# Altium schematic sheet Sheet1.SchDoc(Sheet1)
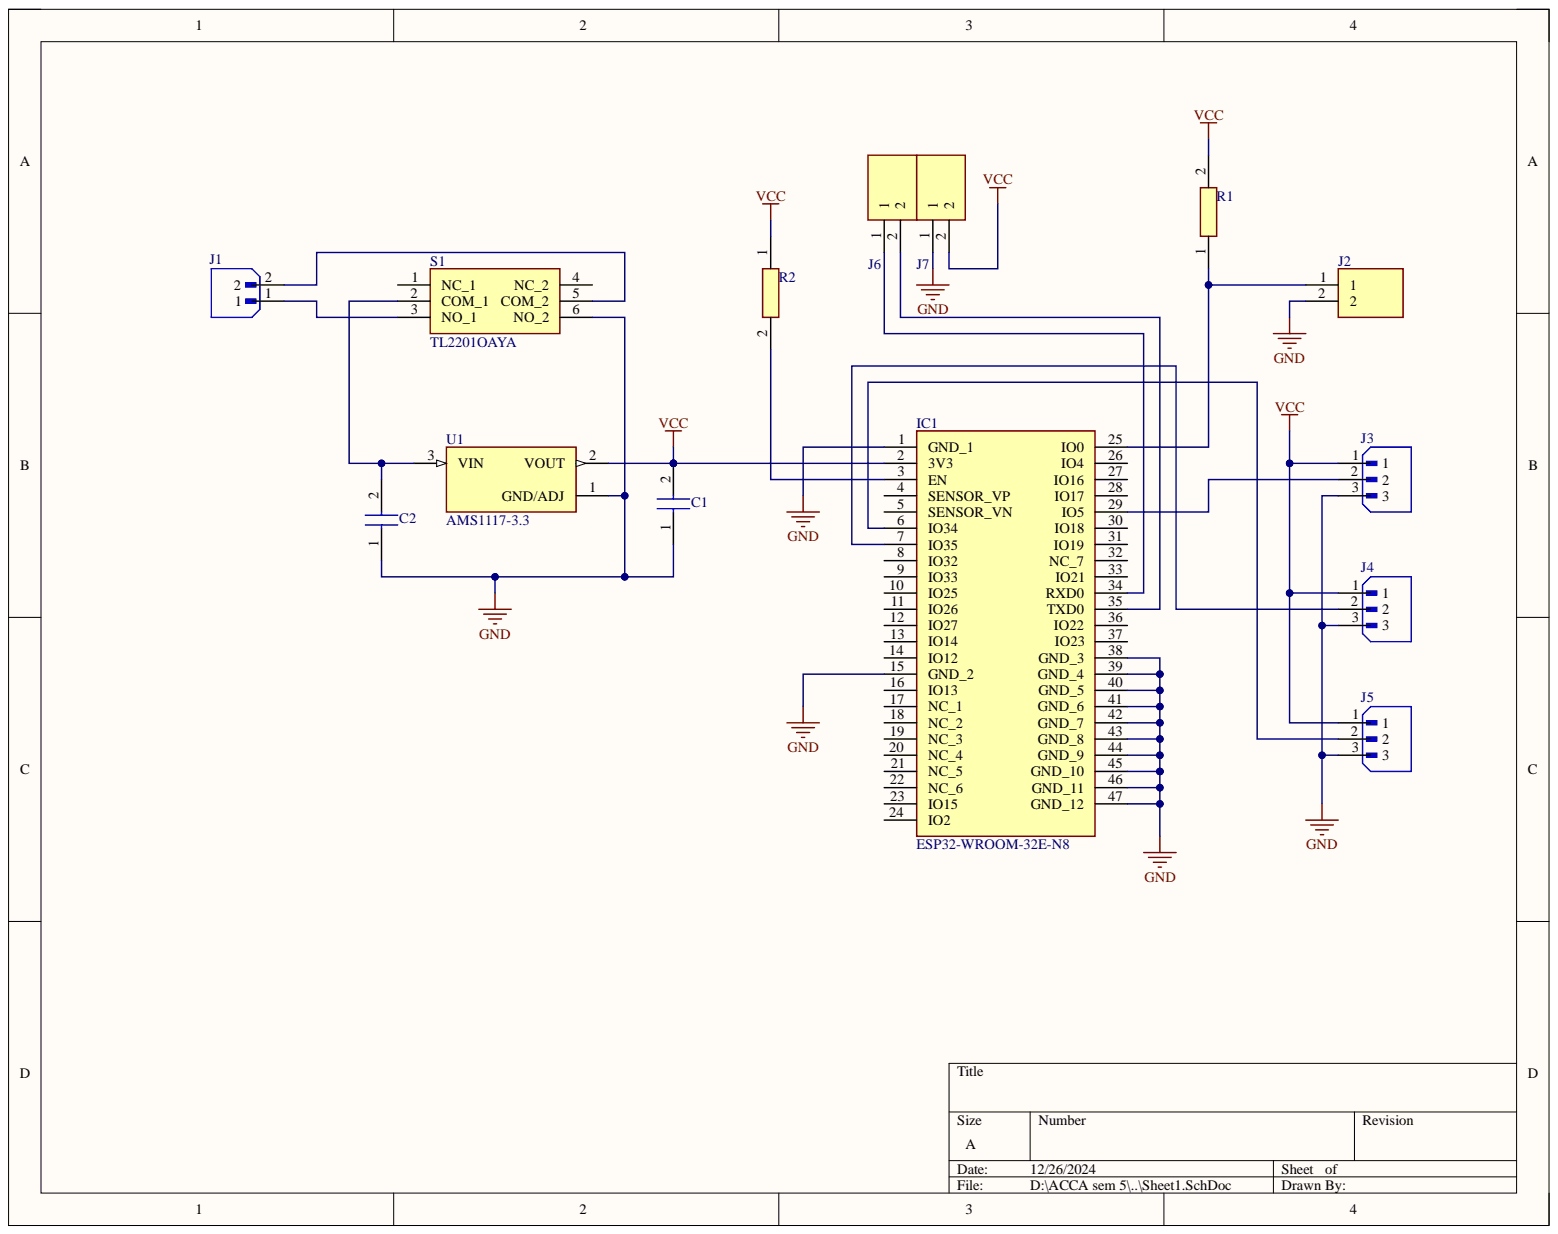
[diagram p0: Sheet1.SchDoc]

## Parts
C1  LMR212BD7106KG-T  [CAPC2114X145N]  (value not drawn)
C2  LMR212BD7106KG-T  [CAPC2114X145N]  (value not drawn)
IC1  ESP32-WROOM-32E-N8  [ESP32WROOM32EN8]
J1  B2B-XH-A_(LF)(SN)  [JST_B2B-XH-A_(LF)(SN)]  (value not drawn)
J2  67996-100HLF  [HDRV2W67P254_2X1_254X483X858P]  (value not drawn)
J3  B3B-XH-A(LF)(SN)  [JST_B3B-XH-A(LF)(SN)]  (value not drawn)
J4  B3B-XH-A(LF)(SN)  [JST_B3B-XH-A(LF)(SN)]  (value not drawn)
J5  B3B-XH-A(LF)(SN)  [JST_B3B-XH-A(LF)(SN)]  (value not drawn)
J6  67996-100HLF  [HDRV2W67P254_2X1_254X483X858P]  (value not drawn)
J7  67996-100HLF  [HDRV2W67P254_2X1_254X483X858P]  (value not drawn)
R1  ERA-6APB103V  [ERA6AEB101V]  (value not drawn)
R2  ERA-6APB103V  [ERA6AEB101V]  (value not drawn)
S1  TL2201OAYA  [TL2201OAZB1CBLK]
U1  AMS1117-3.3  [SOT229P700X180-4N]

## Nets
GND: C1.1 C2.1 IC1.1(GND_1) IC1.15(GND_2) IC1.38(GND_3) IC1.39(GND_4) IC1.40(GND_5) IC1.41(GND_6) IC1.42(GND_7) IC1.43(GND_8) IC1.44(GND_9) IC1.45(GND_10) IC1.46(GND_11) IC1.47(GND_12) J2.2 J3.3 J4.3 J5.3 J7.1 S1.6(NO_2) U1.1
VCC: C1.2 IC1.2(3V3) J3.1 J4.1 J5.1 J7.2 R1.2 R2.1 U1.2
NetC2_2: C2.2 S1.2(COM_1) U1.3
NetIC1_3: IC1.3(EN) R2.2
NetIC1_6: IC1.6(IO34) J5.2
NetIC1_7: IC1.7(IO35) J4.2
NetIC1_25: IC1.25(IO0) J2.1 R1.1
NetIC1_29: IC1.29(IO5) J3.2
NetIC1_34: IC1.34(RXD0) J6.1
NetIC1_35: IC1.35(TXD0) J6.2
NetJ1_1: J1.1 S1.3(NO_1)
NetJ1_2: J1.2 S1.5(COM_2)

Not connected: IC1 4(SENSOR_VP) 5(SENSOR_VN) 8(IO32) 9(IO33) 10(IO25) 11(IO26) 12(IO27) 13(IO14) 14(IO12) 16(IO13) 17(NC_1) 18(NC_2) 19(NC_3) 20(NC_4) 21(NC_5) 22(NC_6) 23(IO15) 24(IO2) 26(IO4) 27(IO16) 28(IO17) 30(IO18) 31(IO19) 32(NC_7) 33(IO21) 36(IO22) 37(IO23); S1 1(NC_1) 4(NC_2)

## Library data of the parts
C1 C2 = LMR212BD7106KG-T: Capacitor; Manufacturer_Name: TAIYO YUDEN; Component Kind: Standard; Datasheet Link: https://www.mouser.de/datasheet/2/396/TAIY_S_A0012830329_1-2514546.pdf; Height: 1.45mm; Manufacturer_Part_Number: LMR212BD7106KG-T; Mouser Part Number: 963-LMR212BD7106KG-T; Mouser Price/Stock: https://www.mouser.co.uk/ProductDetail/Taiyo-Yuden/LMR212BD7106KG-T?qs=DRkmTr78QATXuUQGmCCYPQ%3D%3D
IC1 = ESP32-WROOM-32E-N8: Integrated Circuit; Manufacturer_Name: Espressif Systems; Component Kind: Standard; Datasheet Link: https://www.google.com/url?sa=t&rct=j&q=&esrc=s&source=web&cd=&ved=2ahUKEwjLw4ysybv2AhXFzIUKHZUbB64QFnoECCwQAQ&url=https%3A%2F%2Fwww.espressif.com%2Fsites%2Fdefault%2Ffiles%2Fdocumentation%2Fesp32-wroom-32e_esp32-wroom-32ue_datasheet_en.pdf&usg=AOvVaw1xSL; Height: 3.25mm; Manufacturer_Part_Number: ESP32-WROOM-32E-N8; Mouser Part Number: 356-ESP32WRM32E164PH; Mouser Price/Stock: https://www.mouser.co.uk/ProductDetail/Espressif-Systems/ESP32-WROOM-32E-N8?qs=Li%252BoUPsLEnsLnZW%252BGLgUhw%3D%3D
J1 = B2B-XH-A_(LF)(SN): Connector Header Through Hole 2 position 0.098 (2.50mm); MF: JST Sales; MP: B2B-XH-A (LF)(SN); Check_prices: https://www.snapeda.com/parts/B2B-XH-A%20(LF)(SN)/JST+Sales+America+Inc./view-part/?ref=eda; Component Kind: Standard; Library Name: B2B-XH-A__LF__SN_.IntLib; Package: None; SnapEDA_Link: https://www.snapeda.com/parts/B2B-XH-A%20(LF)(SN)/JST+Sales+America+Inc./view-part/?ref=snap
J2 J6 J7 = 67996-100HLF: Connector; Manufacturer_Name: Amphenol Communications Solutions; Arrow Part Number: 67996-100HLF; Arrow Price/Stock: https://www.arrow.com/en/products/67996-100hlf/amphenol-fci?region=nac; Component Kind: Standard; Datasheet Link: https://cdn.amphenol-cs.com/media/wysiwyg/files/drawing/67996.pdf; Height: 8.58mm; Manufacturer_Part_Number: 67996-100HLF
J3-J5 = B3B-XH-A(LF)(SN): Connector Header Through Hole 3 position 0.098 (2.50mm); MF: JST Sales; Check_prices: https://www.snapeda.com/parts/B3B-XH-A(LF)(SN)/JST+Sales+America+Inc./view-part/?ref=eda; Component Kind: Standard; Library Name: B3B-XH-A_LF__SN_.IntLib; MP: B3B-XH-A(LF)(SN); Package: None; SnapEDA_Link: https://www.snapeda.com/parts/B3B-XH-A(LF)(SN)/JST+Sales+America+Inc./view-part/?ref=snap
R1 R2 = ERA-6APB103V: Resistor; Manufacturer_Name: Panasonic; Component Kind: Standard; Datasheet Link: https://industrial.panasonic.com/cdbs/www-data/pdf/RDM0000/AOA0000C307.pdf; Height: 0.6mm; Manufacturer_Part_Number: ERA-6APB103V; Mouser Part Number: 667-ERA-6APB103V; Mouser Price/Stock: https://www.mouser.co.uk/ProductDetail/Panasonic/ERA-6APB103V?qs=ob%252BdNz2%252BYEhhgEYA52%2FHJA%3D%3D
S1 = TL2201OAYA: Switch; Manufacturer_Name: E-Switch; Component Kind: Standard; Datasheet Link: https://configured-product-images.s3.amazonaws.com/2D/specs/TL2201OAYA.pdf; Height: 20.45mm; Library Name: SamacSys.SchLib; Manufacturer_Part_Number: TL2201OAYA; Mouser Part Number: 612-TL2201; Mouser Price/Stock: https://www.mouser.co.uk/ProductDetail/E-Switch/TL2201OAYA?qs=YXf4ACKMM4w794yp%252BO8lyQ%3D%3D
U1 = AMS1117-3.3: Sot223/Pkg 1-Amp 3.3-Volt Low Drop Out Voltage Regulatator; MF: Advanced Monolithic Systems; Availability: Not in stock; Check_prices: https://www.snapeda.com/parts/AMS1117-3.3/Advanced+Monolithic+Systems/view-part/?ref=eda; Component Kind: Standard; Library Name: AMS1117-3.3.IntLib; MP: AMS1117-3.3; Package: SOT-223 Seeed Technology; Price: None; SnapEDA_Link: https://www.snapeda.com/parts/AMS1117-3.3/Advanced+Monolithic+Systems/view-part/?ref=snap
